# Altium schematic sheet Mako DSP Audio Codec R2.0.SchDoc("Mako DSP Audio Codec R2.0")
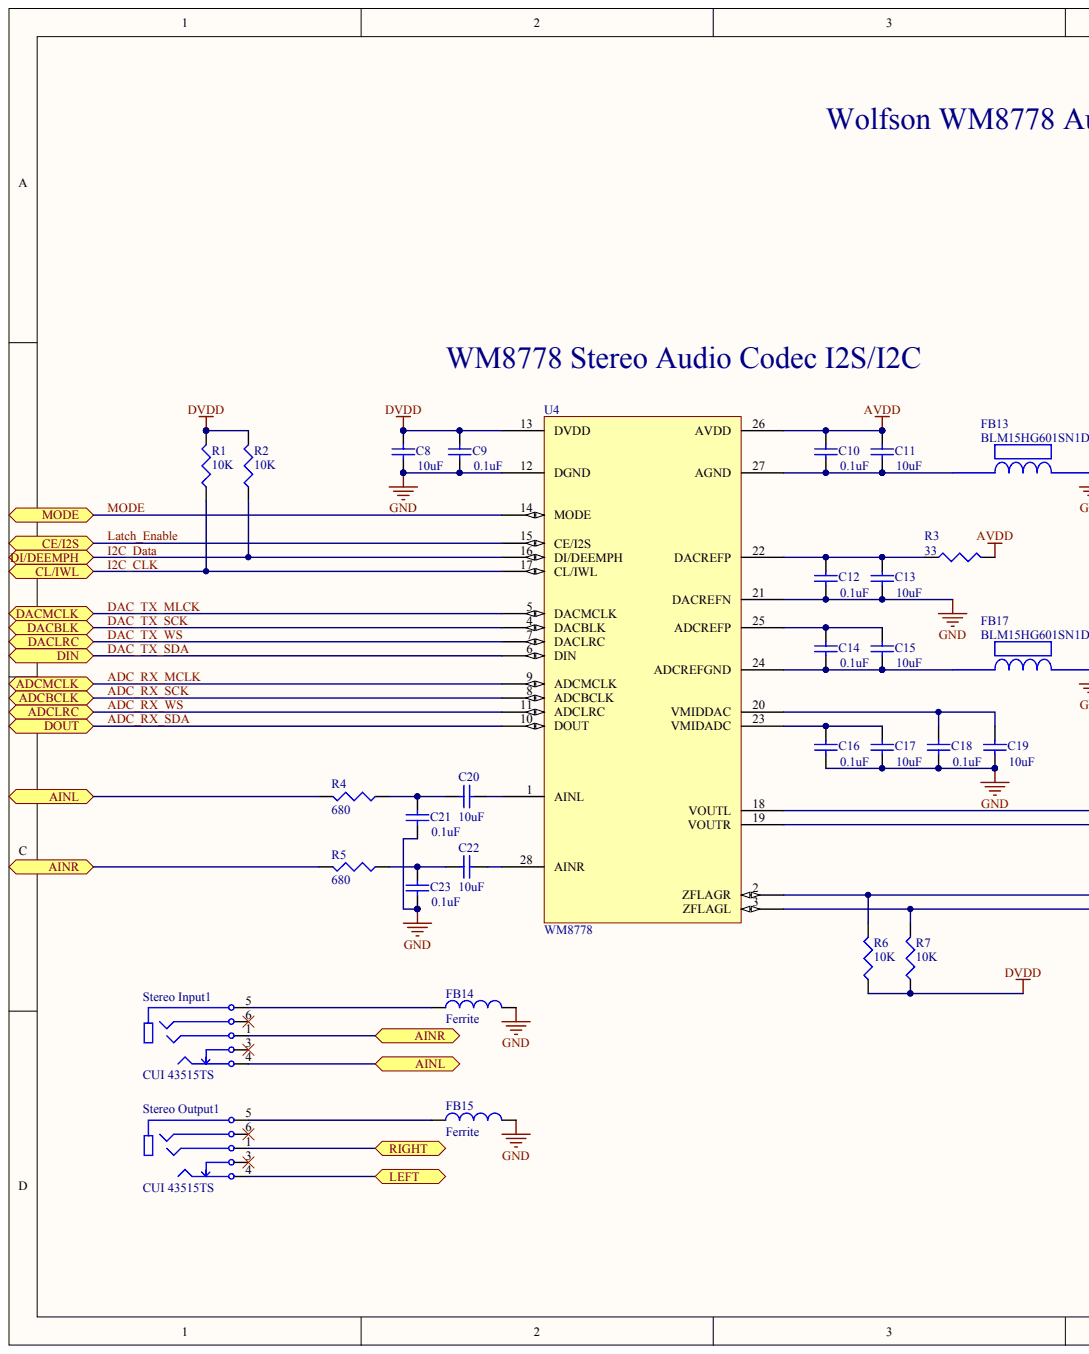
[diagram p0: Mako DSP Audio Codec R2.0.SchDoc, left]
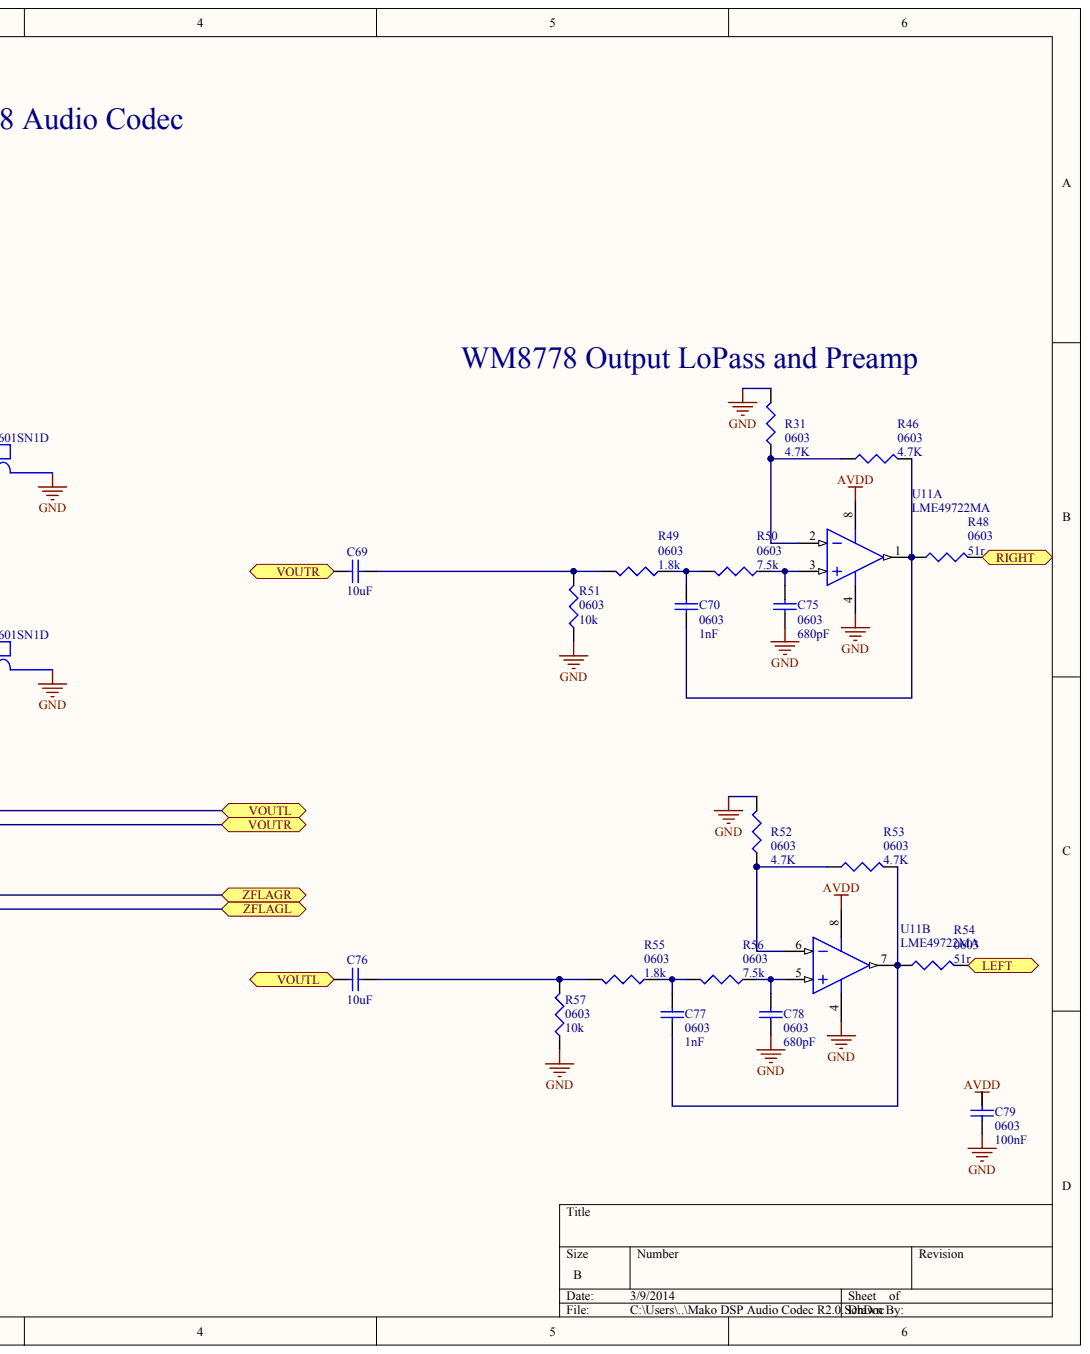
[diagram p1: Mako DSP Audio Codec R2.0.SchDoc, right]

## Parts
C8  value 10uF  (hidden comment: Cap Semi)
C9  value 0.1uF  (hidden comment: Cap Semi)
C10  value 0.1uF  (hidden comment: Cap Semi)
C11  value 10uF  (hidden comment: Cap Semi)
C12  value 0.1uF  (hidden comment: Cap Semi)
C13  value 10uF  (hidden comment: Cap Semi)
C14  value 0.1uF  (hidden comment: Cap Semi)
C15  value 10uF  (hidden comment: Cap Semi)
C16  value 0.1uF  (hidden comment: Cap Semi)
C17  value 10uF  (hidden comment: Cap Semi)
C18  value 0.1uF  (hidden comment: Cap Semi)
C19  value 10uF  (hidden comment: Cap Semi)
C20  value 10uF  (hidden comment: Cap Semi)
C21  value 0.1uF  (hidden comment: Cap Semi)
C22  value 10uF  (hidden comment: Cap Semi)
C23  value 0.1uF  (hidden comment: Cap Semi)
C69  value 10uF  (hidden comment: Cap Semi)
C70  0603  value 1nF
C75  0603  value 680pF
C76  value 10uF  (hidden comment: Cap Semi)
C77  0603  value 1nF
C78  0603  value 680pF
C79  0603  value 100nF
FB13  BLM15HG601SN1D
FB14  Ferrite
FB15  Ferrite
FB17  BLM15HG601SN1D
R1  value 10K  (hidden comment: Res3)
R2  value 10K  (hidden comment: Res3)
R3  value 33  (hidden comment: Res3)
R4  value 680  (hidden comment: Res3)
R5  value 680  (hidden comment: Res3)
R6  value 10K  (hidden comment: Res3)
R7  value 10K  (hidden comment: Res3)
R31  0603  value 4.7K
R46  0603  value 4.7K
R48  0603  value 51r
R49  0603  value 1.8k
R50  0603  value 7.5k
R51  0603  value 10k
R52  0603  value 4.7K
R53  0603  value 4.7K
R54  0603  value 51r
R55  0603  value 1.8k
R56  0603  value 7.5k
R57  0603  value 10k
Stereo_Input1  CUI 43515TS
Stereo_Output1  CUI 43515TS
U4  WM8778
U11  LME49722MA  (parts A,B)

## Ports
ADCBCLK, ADCLRC, ADCMCLK, AINL, AINR, CE/I2S, CL/IWL, DACBLK, DACLRC, DACMCLK, DI/DEEMPH, DIN, DOUT, LEFT, MODE, RIGHT, VOUTL, VOUTR, ZFLAGL, ZFLAGR

## Nets
AVDD: C10.2 C11.2 R3.2 U4.26(AVDD) U11.8
DVDD: C8.2 C9.2 R1.2 R2.2 R6.1 R7.1 U4.13(DVDD)
GND: C8.1 C9.1 C12.1 C13.1 C16.1 C17.1 C18.1 C19.1 C21.1 C23.1 C75.1 C78.1 C79.1 FB13.2 FB14.2 FB15.2 FB17.2 R31.2 R51.1 R52.2 R57.1 U4.12(DGND) U4.21(DACREFN) U11.4
ADC_RX_MCLK: U4.9(ADCMCLK) ; port ADCMCLK
ADC_RX_SCK: U4.8(ADCBCLK) ; port ADCBCLK
ADC_RX_SDA: U4.10(DOUT) ; port DOUT
ADC_RX_WS: U4.11(ADCLRC) ; port ADCLRC
AINL: R4.2 Stereo_Input1.4 ; port AINL
AINR: R5.2 Stereo_Input1.1 ; port AINR
DAC_TX_MLCK: U4.5(DACMCLK) ; port DACMCLK
DAC_TX_SCK: U4.4(DACBLK) ; port DACBLK
DAC_TX_SDA: U4.6(DIN) ; port DIN
DAC_TX_WS: U4.7(DACLRC) ; port DACLRC
I2C_CLK: R1.1 U4.17(CL/IWL) ; port CL/IWL
I2C_DATA: R2.1 U4.16(DI/DEEMPH) ; port DI/DEEMPH
LATCH_ENABLE: U4.15(CE/I2S) ; port CE/I2S
LEFT: R54.2 Stereo_Output1.4 ; port LEFT
MODE: U4.14(MODE) ; port MODE
RIGHT: R48.2 Stereo_Output1.1 ; port RIGHT
VOUTL: C76.1 U4.18(VOUTL) ; port VOUTL
VOUTR: C69.1 U4.19(VOUTR) ; port VOUTR
ZFLAGL: R7.2 U4.3(ZFLAGL) ; port ZFLAGL
ZFLAGR: R6.2 U4.2(ZFLAGR) ; port ZFLAGR
NetC10_1: C10.1 C11.1 FB13.1 U4.27(AGND)
NetC12_2: C12.2 C13.2 R3.1 U4.22(DACREFP)
NetC14_1: C14.1 C15.1 FB17.1 U4.24(ADCREFGND)
NetC14_2: C14.2 C15.2 U4.25(ADCREFP)
NetC16_2: C16.2 C17.2 U4.23(VMIDADC)
NetC18_2: C18.2 C19.2 U4.20(VMIDDAC)
NetC20_1: C20.1 U4.1(AINL)
NetC20_2: C20.2 C21.2 R4.1
NetC22_1: C22.1 U4.28(AINR)
NetC22_2: C22.2 C23.2 R5.1
NetC69_2: C69.2 R49.2 R51.2
NetC70_1: C70.1 R46.2 R48.1 U11.1
NetC70_2: C70.2 R49.1 R50.2
NetC75_2: C75.2 R50.1 U11.3
NetC76_2: C76.2 R55.2 R57.2
NetC77_1: C77.1 R53.2 R54.1 U11.7
NetC77_2: C77.2 R55.1 R56.2
NetC78_2: C78.2 R56.1 U11.5
NetFB14_1: FB14.1 Stereo_Input1.5
NetFB15_1: FB15.1 Stereo_Output1.5
NetR31_1: R31.1 R46.1 U11.2
NetR52_1: R52.1 R53.1 U11.6

Not connected: C79 2; Stereo_Input1 3 6; Stereo_Output1 3 6

## Library data of the parts
FB14 FB15 = (no symbol name): Code_IEC: 1005; Published: 8-Jun-2000; LatestRevisionDate: 17-Jul-2002; LatestRevisionNote: Re-released for DXP Platform.; PackageReference: 0402-A; Code_JEITA: 0402; Publisher: Altium Limited
U11 = (no symbol name): Manufacturer: National Semiconductor; PackageTopMarking: NSZXTT*L49722*MA; PowerWise: N; CompType: Audio IC; High-Reliability: Y; DatasheetVersion: Mar-2008; PackageVersion: revM, May-2009; PackageReference: M08A; PackageDescription: SOIC Narrow, 4.9 x 3.9 x 1.45mm, 8 Lead, 1.27mm Pitch; PartNumber: LME49722MA; RoHS: Y; PinCount: 8; Code_JEDEC: MS-012-AA; Code_IPC: SOIC127P600-8; ComponentLink1Description: Manufacturer URL; ComponentLink1URL: http://www.national.com/; ComponentLink2Description: LME49722 Datasheet; ComponentLink2URL: http://www.national.com/ds/LM/LME49722.pdf; ComponentLink3Description: LME49722 Web Page; ComponentLink3URL: http://www.national.com/pf/LM/LME49722.html; ComponentLink4Description: M08A Package Page; ComponentLink4URL: http://www.national.com/packaging/folders/m08a.html
C8-C23 C69 C70 C75-C79 FB13 FB17 R1-R7 R31 R46 R48-R57 Stereo_Input1 Stereo_Output1 U4 = (no symbol name)
  C8-C23 C69 C70 C75-C79: Published: 8-Jun-2000; LatestRevisionDate: 29-May-2009; LatestRevisionNote: IPC-7351 Footprint Added.; PackageReference: C1206; PackageDescription: Chip Capacitor
  R1-R7 R31 R46 R48-R57: Published: 8-Jun-2000; LatestRevisionDate: 29-May-2009; LatestRevisionNote: IPC-7351 Footprint Added.; PackageReference: J1-0603; PackageDescription: Chip Resistor
  C8-C23 C69 C70 C75-C79 R1-R7 R31 R46 R48-R57: Publisher: Altium Limited
